# Altium schematic sheet [4]-CONNECTORS.SchDoc([4]-CONNECTORS)
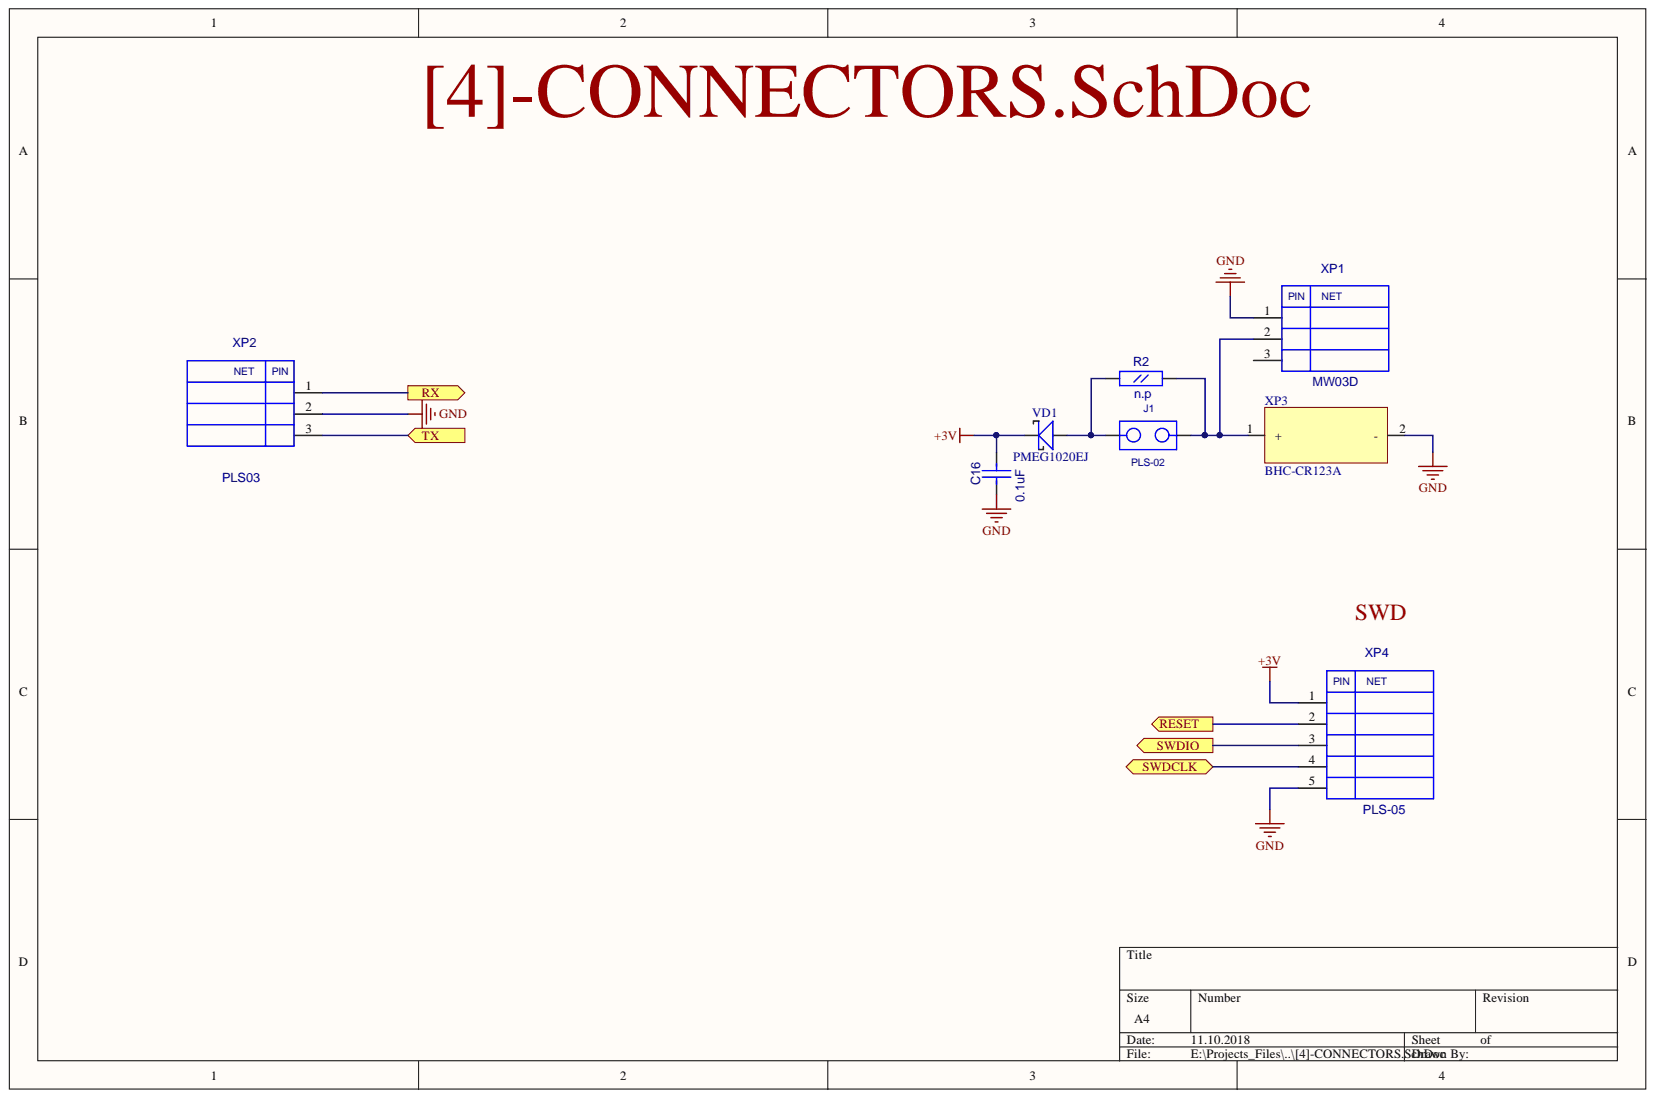
[diagram p0: [4]-CONNECTORS.SchDoc]

## Parts
C16  0.1uF  [CC0603]
J1  PLS-02  [PLS-02]
R2  n.p  [RC0603]
VD1  PMEG1020EJ  [SOD323]
XP1  MW03D  [MW03D]
XP2  PLS03  [PLS-03]
XP3  BHC-CR123A  [CR123_HOLDER]
XP4  PLS-05  [PLS-05]

## Ports
RESET, RX, SWDCLK, SWDIO, TX

## Nets
+3V: C16.2 VD1.2 XP4.1
GND: C16.1 XP1.1 XP2.2 XP3.2 XP4.5
RESET: XP4.2 ; port RESET
RX: XP2.1 ; port RX
SWDCLK: XP4.4 ; port SWDCLK
SWDIO: XP4.3 ; port SWDIO
TX: XP2.3 ; port TX
NetJ1_1: J1.1 R2.1 VD1.1
NetJ1_2: J1.2 R2.2 XP1.2 XP3.1

Not connected: XP1 3

## Library data of the parts
C16 = CC0603: Value: 0.1uF; Description: CC0603; Component Kind: Standard; Library Name: fizik_lib.SchLib
R2 = RC0603: Value: n.p; Description: RC0603; Component Kind: Standard; Library Name: fizik_lib.SchLib
VD1 = PMEG2010: Value: PMEG1020EJ; Component Kind: Standard; Library Name: fizik_lib.SchLib
XP3 = BHC-CR123A: Holder for CR123 battery; Value: BHC-CR123A; Component Kind: Standard; Library Name: fizik_lib.SchLib
J1 = PLS-02: Description: PLS-02; Component Kind: Standard; Library Name: fizik_lib.SchLib
XP1 = MW03D: Description: MW03D; Component Kind: Standard; Library Name: gprs_modem_2.SchLib
XP2 = PLS03: Description: PLS03; Component Kind: Standard; Library Name: fizik_lib.SchLib
XP4 = PLS-05: Description: PLS-05; Component Kind: Standard; Library Name: gprs_modem_2.SchLib
